# Altium schematic sheet Power-Supply.SchDoc(Power-Supply)
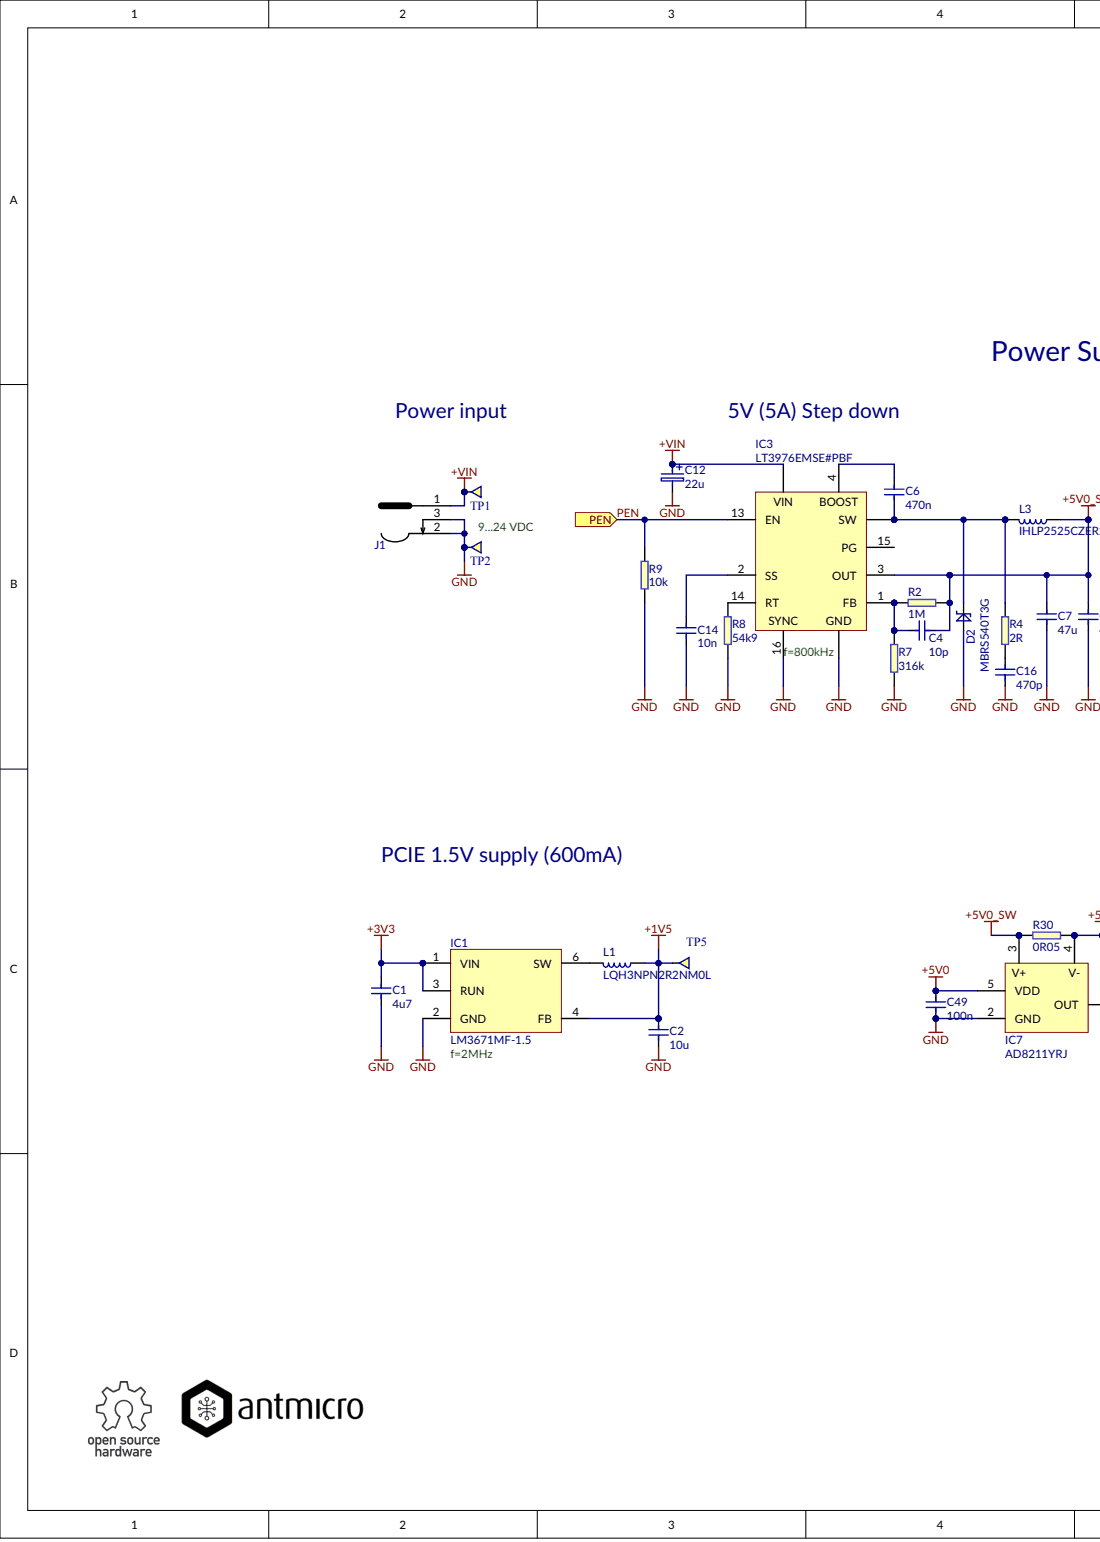
[diagram p0: Power-Supply.SchDoc, left]
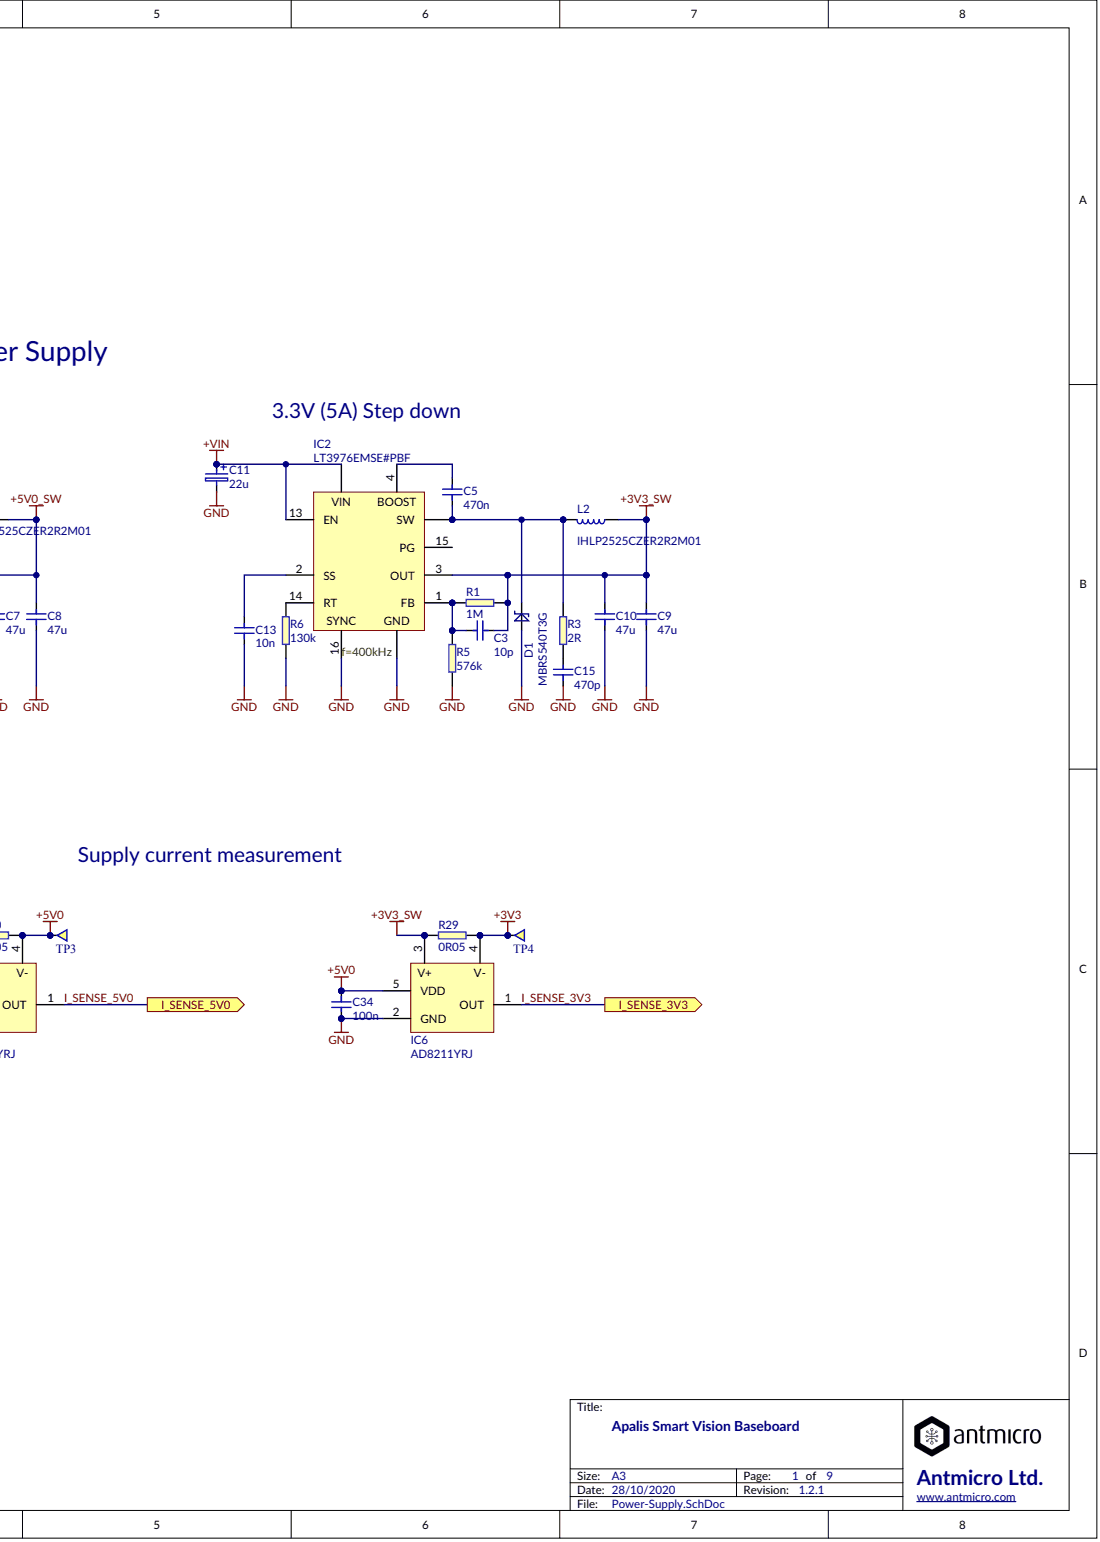
[diagram p1: Power-Supply.SchDoc, right]

## Parts
C1  4u7
C2  10u
C3  10p
C4  10p
C5  470n
C6  470n
C7  47u
C8  47u
C9  47u
C10  47u
C11  22u
C12  22u
C13  10n
C14  10n
C15  470p
C16  470p
C34  100n
C49  100n
D1  MBRS540T3G
D2  MBRS540T3G
IC1  LM3671MF-1.5
IC2  LT3976EMSE#PBF
IC3  LT3976EMSE#PBF
IC6  AD8211YRJ
IC7  AD8211YRJ
J1  694106106102  (value not drawn)
L1  LQH3NPN2R2NM0L
L2  IHLP2525CZER2R2M01
L3  IHLP2525CZER2R2M01
R1  1M
R2  1M
R3  2R
R4  2R
R5  576k
R6  130k
R7  316k
R8  54k9
R9  10k
R29  0R05
R30  0R05
TP1  TP-R-1.5  (value not drawn)
TP2  TP-R-1.5  (value not drawn)
TP3  TP-R-1.5  (value not drawn)
TP4  TP-R-1.5  (value not drawn)
TP5  TP-R-1.5  (value not drawn)

## Ports
I_SENSE_3V3, I_SENSE_5V0, PEN

## Nets
+1V5: C2.2 IC1.4(FB) L1.2 TP5.1
+3V3: C1.2 IC1.1(VIN) IC1.3(RUN) IC6.4(V-) R29.1 TP4.1
+3V3_SW: C3.1 C9.2 C10.2 IC2.3(OUT) IC6.3(V+) L2.2 R1.1 R29.2
+5V0: C34.1 C49.1 IC6.5(VDD) IC7.4(V-) IC7.5(VDD) R30.1 TP3.1
+5V0_SW: C4.1 C7.2 C8.2 IC3.3(OUT) IC7.3(V+) L3.2 R2.1 R30.2
+VIN: C11.1 C12.1 IC2.13(EN) IC2.VIN IC3.VIN J1.1 TP1.1
GND: C1.1 C2.1 C7.1 C8.1 C9.1 C10.1 C11.2 C12.2 C13.1 C14.1 C15.1 C16.1 C34.2 C49.2 D1.A D2.A IC1.2(GND) IC2.16 IC2.GND IC3.16 IC3.GND IC6.2(GND) IC7.2(GND) J1.2 J1.3 R5.1 R6.1 R7.1 R8.1 R9.1 TP2.1
I_SENSE_3V3: IC6.1(OUT) ; port I_SENSE_3V3
I_SENSE_5V0: IC7.1(OUT) ; port I_SENSE_5V0
PEN: IC3.13(EN) R9.2 ; port PEN
NetC3_2: C3.2 IC2.1(FB) R1.2 R5.2
NetC4_2: C4.2 IC3.1(FB) R2.2 R7.2
NetC5_1: C5.1 D1.C IC2.SW L2.1 R3.2
NetC5_2: C5.2 IC2.4
NetC6_1: C6.1 D2.C IC3.SW L3.1 R4.2
NetC6_2: C6.2 IC3.4
NetC13_2: C13.2 IC2.2(SS)
NetC14_2: C14.2 IC3.2(SS)
NetC15_2: C15.2 R3.1
NetC16_2: C16.2 R4.1
NetIC1_6: IC1.6(SW) L1.1
NetIC2_14: IC2.14(RT) R6.2
NetIC3_14: IC3.14(RT) R8.2

Not connected: IC2 15(PG); IC3 15(PG)

## Library data of the parts
C1 = (no symbol name): Manufacturer: MURATA; Part Number: C_4u7_0402; Part Symbol: GRM155R60G475ME; Value: 4u7; MPN: GRM155R60G475ME
C2 = (no symbol name): Manufacturer: Walsin; Part Number: C_10u_0603; Part Symbol: 0603X106M6R3CT; Value: 10u; MPN: 0603X106M6R3CT
C3 C4 = (no symbol name): Manufacturer: MULTICOMP; Part Number: C_10p_0402; Part Symbol: MC0402N100D500CT; Value: 10p; MPN: MC0402N100D500CT
C5 C6 = (no symbol name): Manufacturer: TDK; Part Number: C_470n_0402; Part Symbol: MC0402X474K6R3CT; Value: 470n; MPN: MC0402X474K6R3CT
C7-C10 = (no symbol name): Manufacturer: MURATA; Part Number: C_47u_1206; Part Symbol: GRM31CC80J476KE18L; Value: 47u; MPN: GRM31CC80J476KE18L
C11 C12 = (no symbol name): Part Number: C_22u_KEMET_C; Manufacturer: KEMET; Part Symbol: T491C226K025AT; Value: 22u; MPN: T491C226K025AT
C13 C14 = (no symbol name): Manufacturer: AVX; Part Number: C_10n_0402; Part Symbol: 04025G103ZAT2A; Value: 10n; MPN: 04025G103ZAT2A
C15 C16 = (no symbol name): Manufacturer: MULTICOMP; Part Number: C_470p_0402; Part Symbol: MC0402B471J500CT; Value: 470p; MPN: MC0402B471J500CT
C34 C49 = (no symbol name): Manufacturer: TDK; Part Number: C_100n_0402; Part Symbol: C1005X5R0J104K050BA; Value: 100n; MPN: C1005X5R0J104K050BA
D1 D2 = (no symbol name): Manufacturer: ON SEMICONDUCTOR; Part Number: MBRS540T3G; Value: MBRS540T3G; Part Symbol: MBRS540T3G; MPN: MBRS540T3G
IC1 = (no symbol name): Manufacturer: TEXAS INSTRUMENTS; Part Symbol: LM3671MF-1.5/NOPB; Part Number: LM3671MF-1.5; Value: LM3671MF-1.5; MPN: LM3671MF-1.5/NOPB
IC2 IC3 = (no symbol name): Manufacturer: LINEAR TECHNOLOGY; Part Number: LT3976EMSE#PBF; Value: LT3976EMSE#PBF; Part Symbol: LT3976EMSE#PBF; MPN: LT3976EMSE#PBF
IC6 IC7 = (no symbol name): Manufacturer: ANALOG DEVICES; Part Symbol: AD8211YRJ; Value: AD8211YRJ; MPN: AD8211YRJ
J1 = (no symbol name): Part Number: WE-694106106102; Manufacturer: WURTH ELEKTRONIK; Value: 694106106102; Part Symbol: 694106106102; MPN: 694106106102
L1 = (no symbol name): Manufacturer: MURATA; Value: LQH3NPN2R2NM0L; Part Number: LQH3NPN2R2NM0L; Part Symbol: LQH3NPN2R2NM0L; MPN: LQH3NPN2R2NM0L
L2 L3 = (no symbol name): Manufacturer: VISHAY; Part Symbol: IHLP2525CZER3R3M01; Part Number: IHLP2525CZER2R2M01; Value: IHLP2525CZER2R2M01; MPN: IHLP2525CZER3R3M01
R1 R2 = (no symbol name): Manufacturer: VISHAY; Part Number: R_1M_0402; Part Symbol: CRCW04021M00FKED; Value: 1M; MPN: CRCW04021M00FKED
R3 R4 = (no symbol name): Manufacturer: YAGEO; Part Number: R_2R_0402; Part Symbol: MC00625W040212R0; Value: 2R; MPN: MC00625W040212R0
R5 = (no symbol name): Manufacturer: MULTICOMP; Part Number: R_576k_0402; Part Symbol: MCMR04X5763FTL; Value: 576k; MPN: MCMR04X5763FTL
R6 = (no symbol name): Manufacturer: MULTICOMP; Part Number: R_130k_0402; Part Symbol: MCMR04X1303FTL; Value: 130k; MPN: MCMR04X1303FTL
R7 = (no symbol name): Manufacturer: VISHAY; Part Number: R_316k_0402; Part Symbol: CRCW0402316KFKED; Value: 316k; MPN: CRCW0402316KFKED
R8 = (no symbol name): Manufacturer: MULTICOMP; Part Number: R_54k9_0402; Part Symbol: MCMR04X5492FTL; Value: 54k9; MPN: MCMR04X5492FTL
R9 = (no symbol name): Manufacturer: MULTICOMP; Part Number: R_10k_0402; Part Symbol: MCMR04X1002FTL; Value: 10k; MPN: MCMR04X1002FTL
R29 R30 = (no symbol name): Manufacturer: PANASONIC; Part Number: R_0R05_1206; Part Symbol: ERJL08KF50MV; Value: 0R05; MPN: ERJL08KF50MV
TP1-TP5 = (no symbol name): Part Number: TP-R-1.5
